# Altium schematic sheet power.SchDoc(power)
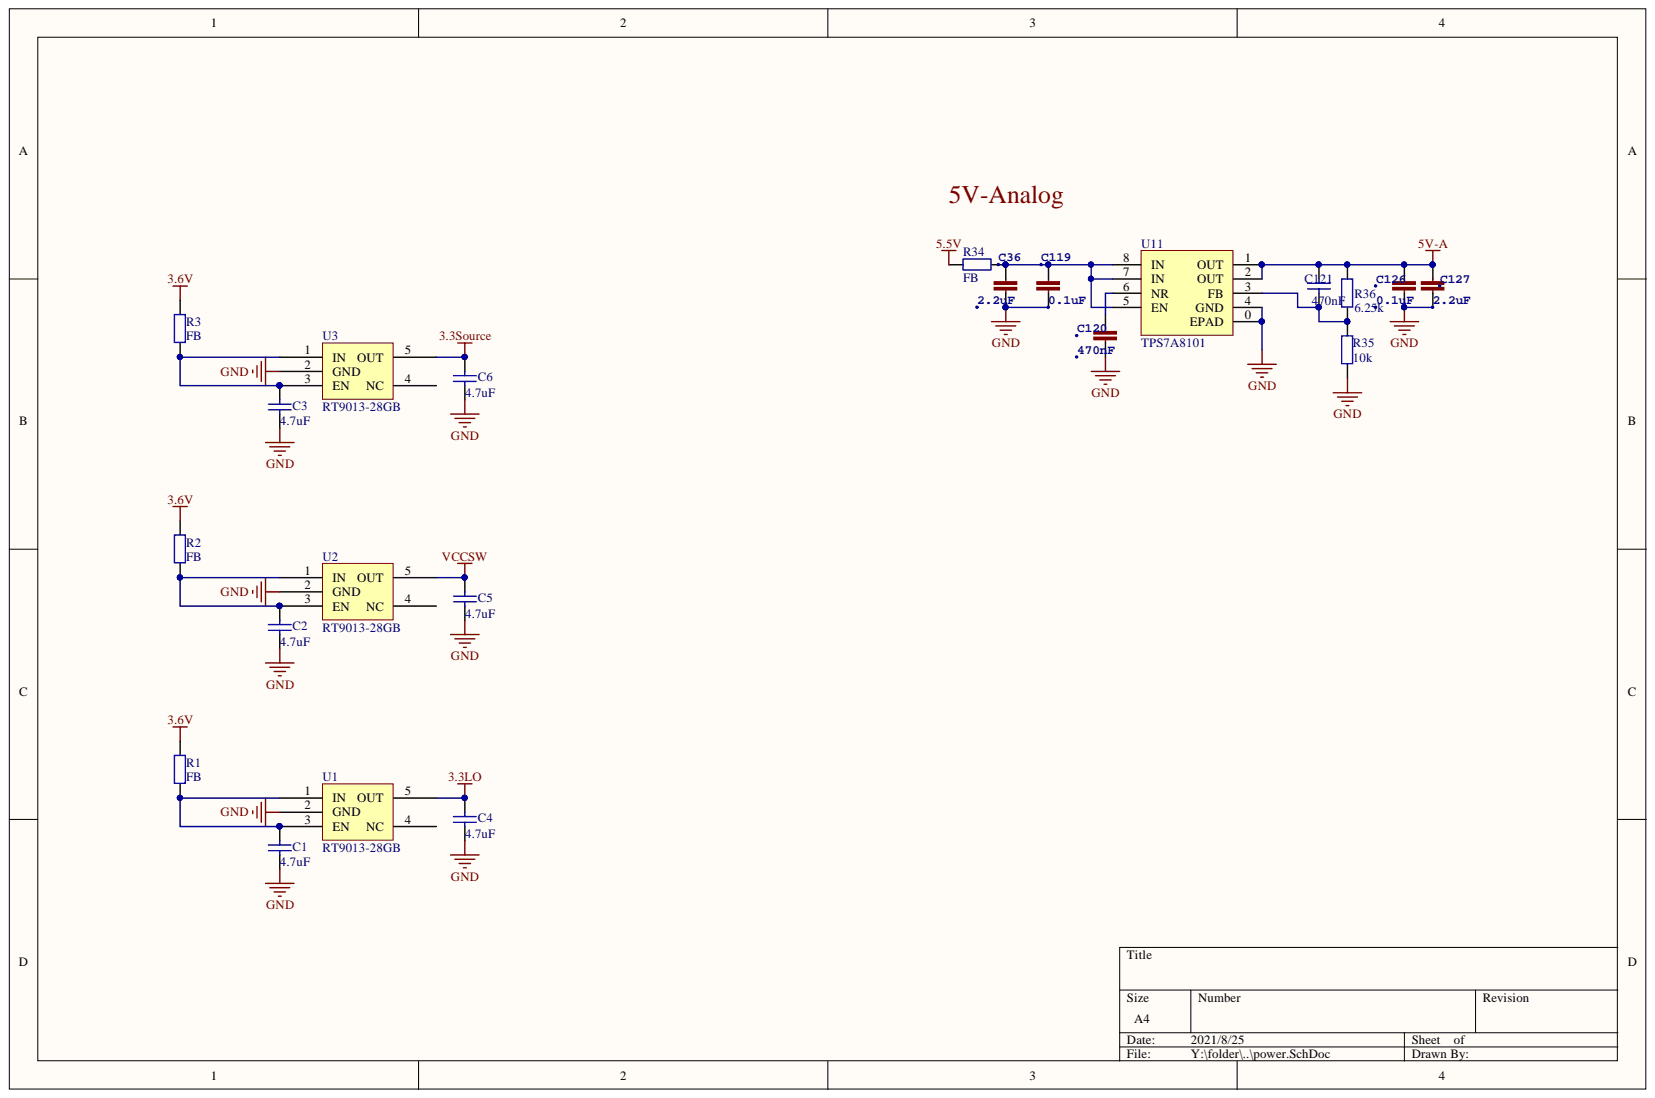
[diagram p0: power.SchDoc]

## Parts
C1  4.7uF  [0603L]
C2  4.7uF  [0603L]
C3  4.7uF  [0603L]
C4  4.7uF  [0603L]
C5  4.7uF  [0603L]
C6  4.7uF  [0603L]
C36  2.2uF  [0603L]
C119  0.1uF  [0402]
C120  470nF  [0402]
C121  470nF  [0402]
C126  0.1uF  [0603L]
C127  2.2uF  [0603L]
R1  FB  [0603L]
R2  FB  [0603L]
R3  FB  [0603L]
R34  FB  [0603L]
R35  10k  [0402]
R36  6.25k  [0402]
U1  RT9013-28GB  [SOT23-5]
U2  RT9013-28GB  [SOT23-5]
U3  RT9013-28GB  [SOT23-5]
U11  TPS7A8101  [TPS7A8101]

## Nets
3.3LO: C4.2 U1.5(OUT)
3.3Source: C6.2 U3.5(OUT)
3.6V: R1.2 R2.2 R3.2
5.5V: R34.2
5V-A: C121.1 C126.2 C127.2 R36.1 U11.1(OUT) U11.2(OUT)
GND: C1.1 C2.1 C3.1 C4.1 C5.1 C6.1 C36.1 C119.1 C120.1 C126.1 C127.1 R35.2 U1.2(GND) U2.2(GND) U3.2(GND) U11.0(EPAD) U11.4(GND)
VCCSW: C5.2 U2.5(OUT)
NetC1_2: C1.2 R1.1 U1.1(IN) U1.3(EN)
NetC2_2: C2.2 R2.1 U2.1(IN) U2.3(EN)
NetC3_2: C3.2 R3.1 U3.1(IN) U3.3(EN)
NetC36_2: C36.2 C119.2 R34.1 U11.5(EN) U11.7(IN) U11.8(IN)
NetC120_2: C120.2 U11.6(NR)
NetC121_2: C121.2 R35.1 R36.2 U11.3(FB)

Not connected: U1 4(NC); U2 4(NC); U3 4(NC)

## Library data of the parts
C1-C6 C121 = Cap: Capacitor; Simulation: CAP; Signal Integrity: Cap; Published: 8-Jun-2000; PackageReference: RAD-0.3; PackageDescription: Radial Cap, Thru-Hole; 2 Leads; 0.3 in Pin Spacing; Library Name: RTC6705.SchLib; LatestRevisionNote: Re-released for DXP Platform.; LatestRevisionDate: 17-Jul-2002; Component Kind: Standard; Publisher: Altium Limited
C36 C119 C120 C126 C127 = CAP0402-CAP: <b>Capacitor</b> Standard 0603 ceramic capacitor, and 0.1" leaded capacitor.; PARTNO: CC0402ZRY5V7BB104; Component Kind: Standard
  C36 C127: Library Name: pixhawk2.IntLib
R1-R3 R34-R36 = Res2: Resistor; Value: 0Ohm; Simulation: RESISTOR; Signal Integrity: Res; Published: 8-Jun-2000; PackageReference: AXIAL-0.4; PackageDescription: Axial Device, Thru-Hole; 2 Leads; 0.4 in Pin Spacing; Library Name: Miscellaneous Devices.IntLib; LatestRevisionNote: Re-released for DXP Platform.; LatestRevisionDate: 17-Jul-2002; Component Kind: Standard; Publisher: Altium Limited
U1-U3 = LP5907: Library Name: RTC6705.SchLib; Component Kind: Standard
U11 = TPS7A8101: Library Name: F4SDR.SchLib; Component Kind: Standard
